# Altium schematic sheet Sheet.SchDoc(Sheet)
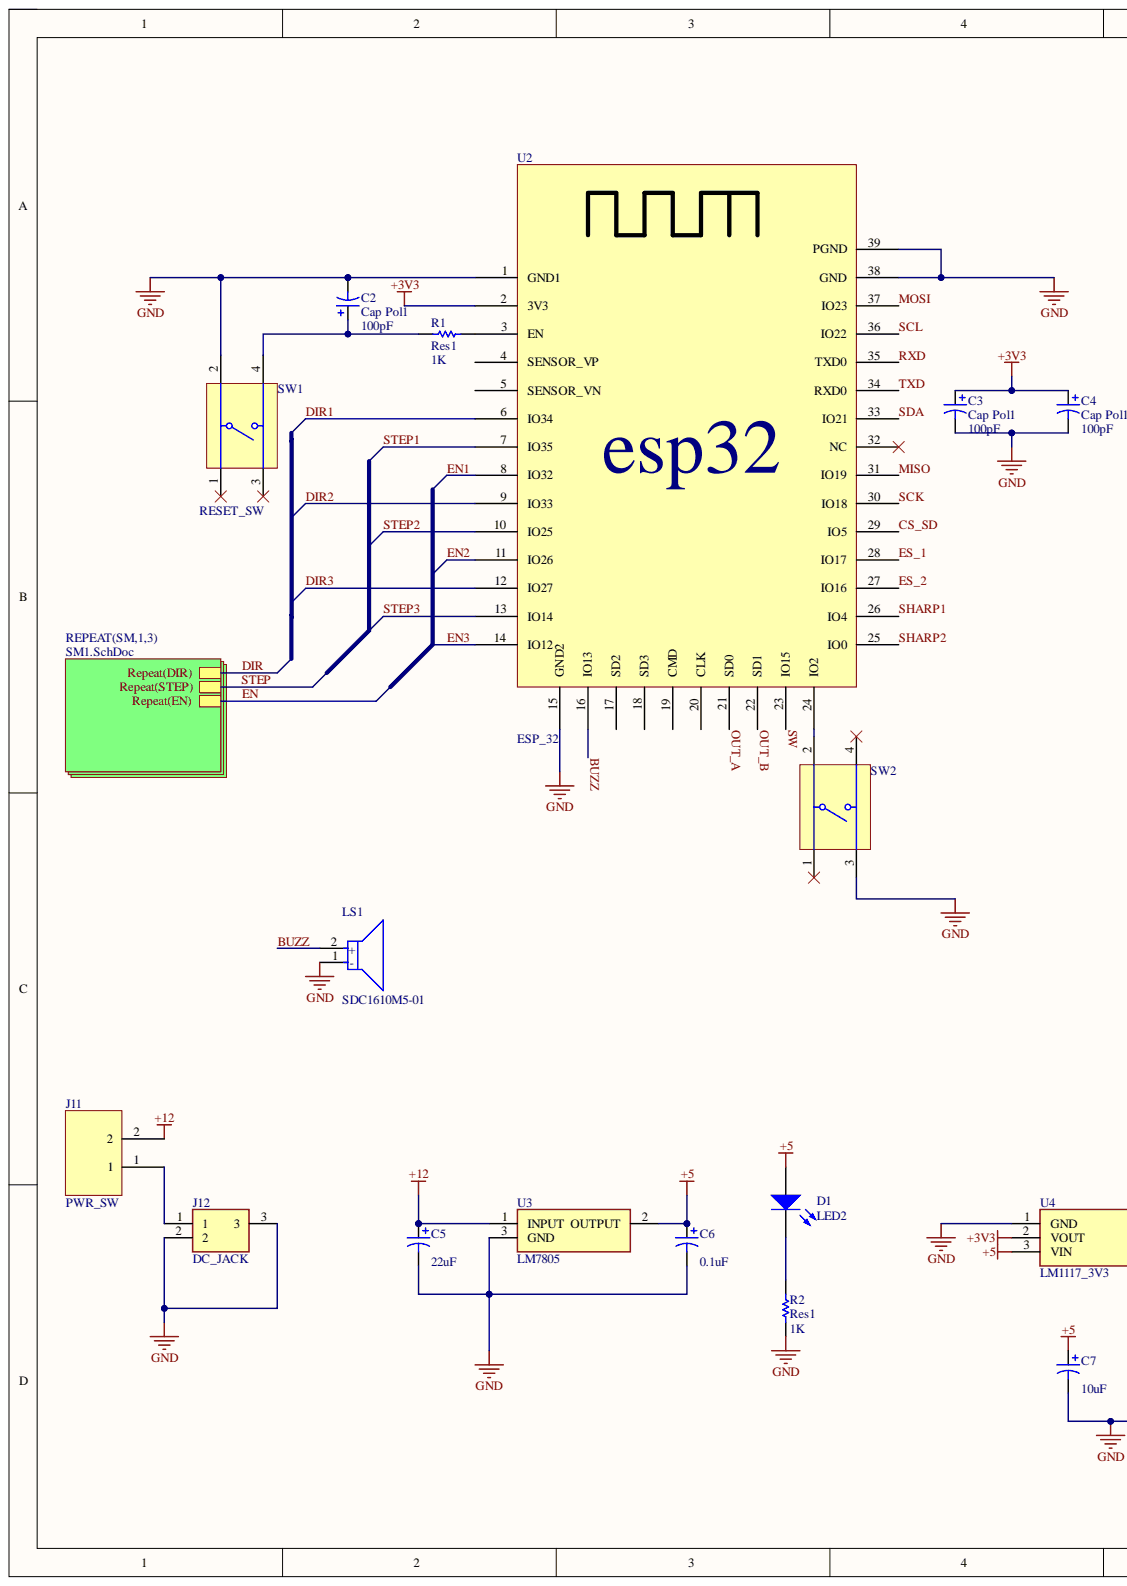
[diagram p0: Sheet.SchDoc, left]
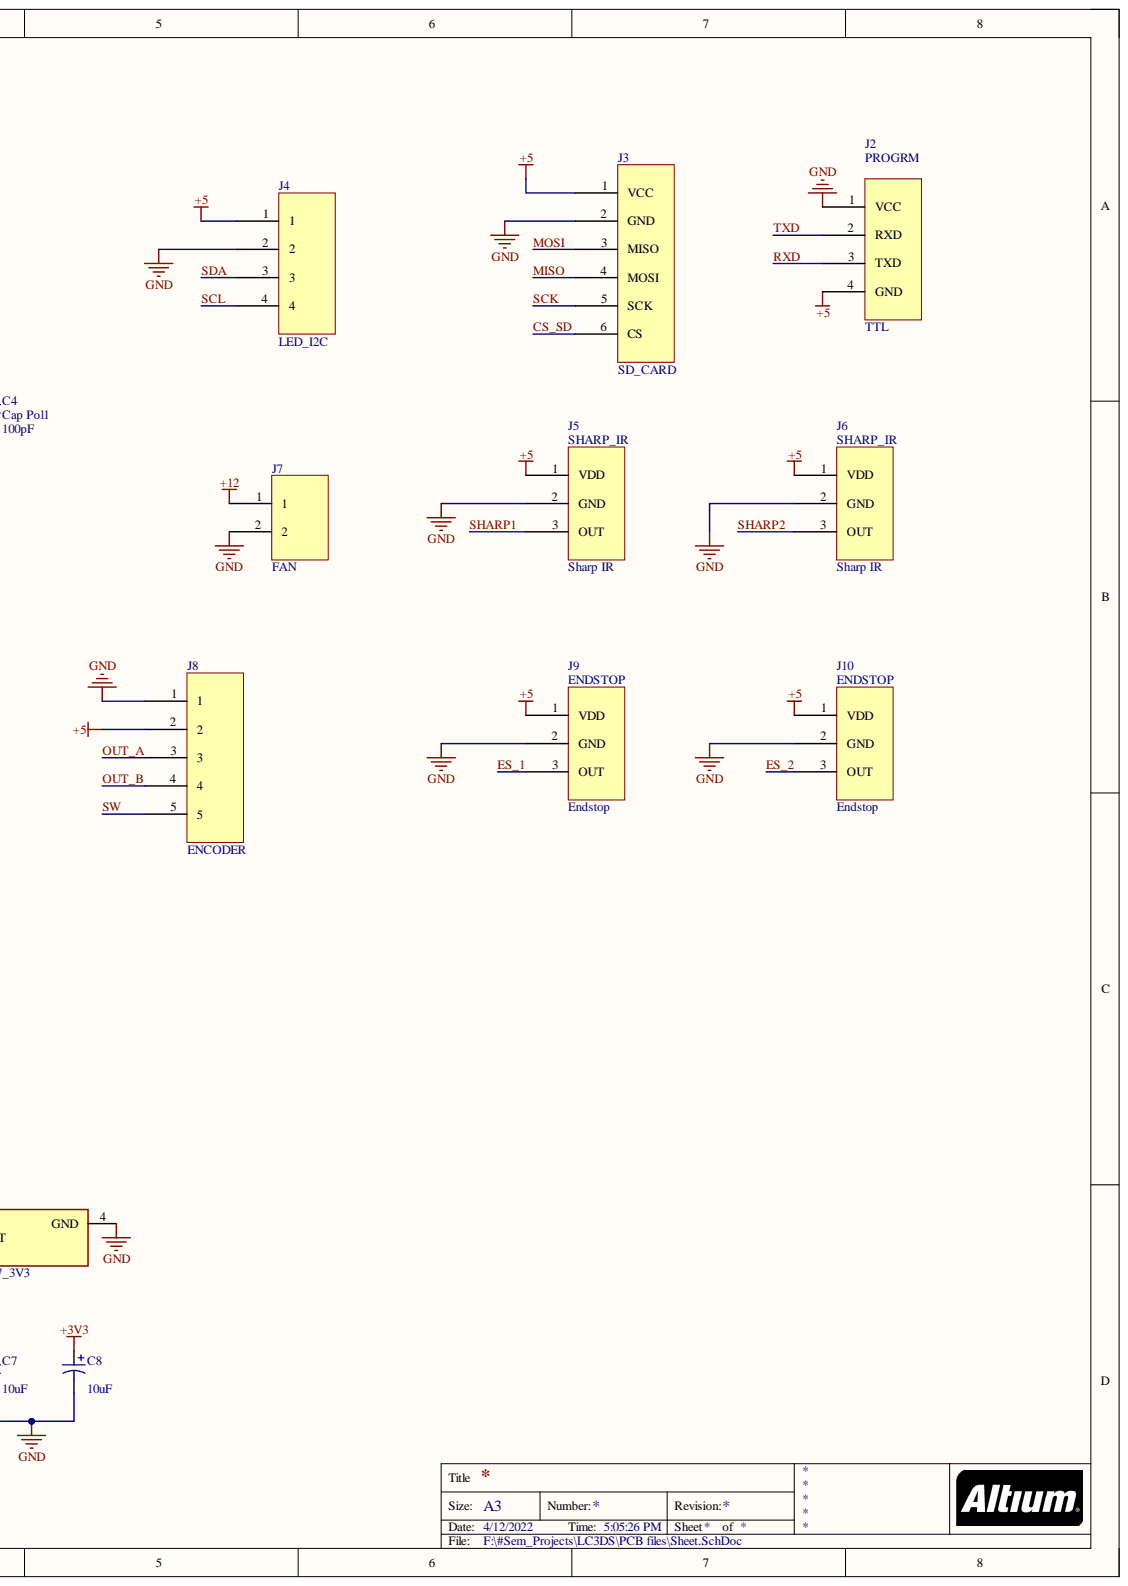
[diagram p1: Sheet.SchDoc, right]

## Parts
C2  Cap Pol1  value 100pF  [6-0805_N]
C3  Cap Pol1  value 100pF  [6-0805_N]
C4  Cap Pol1  value 100pF  [6-0805_N]
C5  value 22uF  [6-0805_N]  (hidden comment: Cap Pol1)
C6  value 0.1uF  [6-0805_N]  (hidden comment: Cap Pol1)
C7  value 10uF  [6-0805_N]  (hidden comment: Cap Pol1)
C8  value 10uF  [6-0805_N]  (hidden comment: Cap Pol1)
D1  LED2  [3.2X1.6X1.1]
J2  TTL  [TTL]
J3  SD_CARD  [SD_CARD]
J4  LED_I2C  [JST_4P]
J5  Sharp IR  [SHARP_IR]
J6  Sharp IR  [SHARP_IR]
J7  FAN  [JST_2P]
J8  ENCODER  [JST_5P]
J9  Endstop  [ENDSTOP]
J10  Endstop  [ENDSTOP]
J11  PWR_SW  [JST_2P]
J12  DC_JACK  [DC_JACK]
LS1  SDC1610M5-01  [FP-SDC1610M5-01-MFG]
R1  Res1  value 1K  [6-0805_N]
R2  Res1  value 1K  [6-0805_N]
SW1  RESET_SW  [TECO-1825910-6_V]
SW2  1825910-6  [TECO-1825910-6_V]  (value not drawn)
U2  ESP_32  [ESP_SoC_Module]
U3  LM7805  [LM7805]
U4  LM1117_3V3  [LM1117_3V3]

## Nets
+3V3: C3.1 C4.1 C8.1 U2.2(3V3) U4.2(VOUT)
+5: C6.1 C7.1 D1.1 J2.4(GND) J3.1(VCC) J4.1 J5.1 J6.1 J8.2 J9.1 J10.1 U3.2 U4.3(VIN)
+12: C5.1 J7.1 J11.2 U3.1
GND: C2.2 C3.2 C4.2 C5.2 C6.2 C7.2 C8.2 J2.1(VCC) J3.2(GND) J4.2 J5.2 J6.2 J7.2 J8.1 J9.2 J10.2 J12.2 J12.3 LS1.1 R2.1 SW1.2 SW2.3 U2.1(GND1) U2.15(GND2) U2.38(GND) U2.39(PGND) U3.3 U4.1(GND) U4.4(GND)
BUZZ: LS1.2 U2.16(IO13)
CS_SD: J3.6(CS) U2.29(IO5)
DIR1: U2.6(IO34)
DIR2: U2.9(IO33)
DIR3: U2.12(IO27)
EN1: U2.8(IO32)
EN2: U2.11(IO26)
EN3: U2.14(IO12)
ES_1: J9.3 U2.28(IO17)
ES_2: J10.3 U2.27(IO16)
MISO: J3.4(MOSI) U2.31(IO19)
MOSI: J3.3(MISO) U2.37(IO23)
OUT_A: J8.3 U2.21(SD0)
OUT_B: J8.4 U2.22(SD1)
RXD: J2.3(TXD) U2.35(TXD0)
SCK: J3.5(SCK) U2.30(IO18)
SCL: J4.4 U2.36(IO22)
SDA: J4.3 U2.33(IO21)
SHARP1: J5.3 U2.26(IO4)
SHARP2: J6.3 U2.25(IO0)
STEP1: U2.7(IO35)
STEP2: U2.10(IO25)
STEP3: U2.13(IO14)
SW: J8.5 U2.23(IO15)
TXD: J2.2(RXD) U2.34(RXD0)
NetC2_1: C2.1 R1.1 SW1.4
NetD1_2: D1.2 R2.2
NetJ11_1: J11.1 J12.1
NetR1_2: R1.2 U2.3(EN)
NetSW1_1: SW1.1
NetSW1_3: SW1.3
NetSW2_1: SW2.1
NetSW2_2: SW2.2 U2.24(IO2)
NetSW2_4: SW2.4
NetU2_4: U2.4(SENSOR_VP)
NetU2_5: U2.5(SENSOR_VN)
NetU2_17: U2.17(SD2)
NetU2_18: U2.18(SD3)
NetU2_19: U2.19(CMD)
NetU2_20: U2.20(CLK)
NetU2_32: U2.32(NC)

## Library data of the parts
C2-C8 = Cap Pol1: Polarized Capacitor (Radial); Component Kind: Standard; LatestRevisionDate: 17-Jul-2002; LatestRevisionNote: Re-released for DXP Platform.; Library Name: Miscellaneous Devices.SchLib; PackageDescription: Polarized Capacitor (Radial); 2 Leads; PackageReference: RB7.6-15; Published: 8-Jun-2000; Signal Integrity: Cap Pol; Simulation: CAP; Publisher: Altium Limited
D1 = LED2: Typical RED, GREEN, YELLOW, AMBER GaAs LED; Component Kind: Standard; LatestRevisionDate: 17-Jul-2002; LatestRevisionNote: Re-released for DXP Platform.; Library Name: Miscellaneous Devices.IntLib; PackageDescription: SM LED; 2 Flat Leads; PackageReference: 3.2X1.6X1.1; PackageVersion: Feb-2002; Published: 8-Jun-2000; Simulation: LED2; Publisher: Altium Limited
J4 = JST_4P: Component Kind: Standard; Library Name: Schlib.SchLib
J5 J6 = SHARP_IR: Component Kind: Standard; Library Name: Schlib.SchLib
J7 J11 = JST_2P: Component Kind: Standard; Library Name: Schlib.SchLib
J8 = JST_5P: Component Kind: Standard; Library Name: Schlib.SchLib
LS1 = CMP-08413-000008-1: AUDIO MAGNETIC INDICATOR 4-8V TH; Frequency: 2.4kHz; Input Type: DC; Max Supply Current: 30mA; Nominal Supply Voltage (DC): 5V; Number of Terminals/Pins: 2; Component Kind: Standard; Diameter: 16mm; Height: 10mm; Lead Free: Lead Free; Library Name: Altium Content Vault; Max Operating Temperature: 70°C; Min Operating Temperature: -10°C; Mount: Through Hole; Number of Pins: 2; Operating Supply Current: 30mA; Packaging: Tray; Sensing Method: Magnetic; Series: SDC; Termination: PC Pins; Voltage Rating: 5V
R1 R2 = Res1: Resistor; Component Kind: Standard; LatestRevisionDate: 17-Jul-2002; LatestRevisionNote: Re-released for DXP Platform.; Library Name: Miscellaneous Devices.IntLib; PackageDescription: Axial Device, Thru-Hole; 2 Leads; 0.3 in Pin Spacing; PackageReference: AXIAL-0.3; Published: 8-Jun-2000; Signal Integrity: Res; Simulation: RESISTOR; Publisher: Altium Limited
SW1 = CMP-1684-00021-1: Tact Switch, SPST-NO, 0.05 A, -35 to 85 degC, 4-Pin THD, RoHS, Bulk; Manufacturer: TE Connectivity; Part No: 1825910-6; Actuator Color: Black; Actuator Length: 1.4mm; Actuator Material: PolybutyleneTerephthalate; Actuator Style: RoundButton; Body Orientation: Straight; Bushing Type: Unthreaded; Contact Rating @ Voltage: 0.05A@24VDC; Dielectric Strength: 500VAC; Electrical Life: 100000Cycles; Function: MomentaryContact; Illumination: N/R; Maximum Contact Resistance: 100mOhm; Maximum DC Voltage Rating: 24V; Pole Throw Configuration: SPST; Switch Configuration: OFF(ON); Base Material: N/A; Component Kind: Standard; Contact Material: CopperAlloy; Contact Plating: Silver; Datasheet URL: http://www.te.com/commerce/DocumentDelivery/DDEController?Action=showdoc&DocId=Customer+Drawing%7F1825910%7FC9%7Fpdf%7FEnglish%7FENG_CD_1825910_C9.pdf%7F1825910-6; Datasheet Version: Rev. C9; Dimension: 5.99x5mm; Family: 1825910; Housing Material: N/A; Library Name: Altium Content Vault; Manufacturer URL: http://www.te.com; Maximum AC Voltage Rating: N/A; Mechanical Life: 100000Cycles; Minimum Insulation Resistance: 100MOhm; Mounting: ThroughHole; Operating Temperature: -35to85C; Package Description: 4-Pin Through Hole Device, Body 5.99 x 5.99 mm, Pitch 6.5 mm; Package Reference: THD; Packaging: N/A; Product Weight: N/A; RoHS: Yes; Seal Description: N/A; Seal Location: N/A; Terminal Type: PCPins; Thread Size: N/R
SW2 = CMP-1684-00021-1: Tact Switch, SPST-NO, 0.05 A, -35 to 85 degC, 4-Pin THD, RoHS, Bulk; Manufacturer: TE Connectivity; Actuator Color: Black; Actuator Length: 1.4mm; Actuator Material: PolybutyleneTerephthalate; Actuator Style: RoundButton; Body Orientation: Straight; Bushing Type: Unthreaded; Contact Rating @ Voltage: 0.05A@24VDC; Dielectric Strength: 500VAC; Electrical Life: 100000Cycles; Function: MomentaryContact; Illumination: N/R; Maximum Contact Resistance: 100mOhm; Maximum DC Voltage Rating: 24V; Pole Throw Configuration: SPST; Switch Configuration: OFF(ON); Base Material: N/A; Component Kind: Standard; Contact Material: CopperAlloy; Contact Plating: Silver; Datasheet URL: http://www.te.com/commerce/DocumentDelivery/DDEController?Action=showdoc&DocId=Customer+Drawing%7F1825910%7FC9%7Fpdf%7FEnglish%7FENG_CD_1825910_C9.pdf%7F1825910-6; Datasheet Version: Rev. C9; Dimension: 5.99x5mm; Family: 1825910; Housing Material: N/A; Library Name: Altium Content Vault; Manufacturer URL: http://www.te.com; Maximum AC Voltage Rating: N/A; Mechanical Life: 100000Cycles; Minimum Insulation Resistance: 100MOhm; Mounting: ThroughHole; Operating Temperature: -35to85C; Package Description: 4-Pin Through Hole Device, Body 5.99 x 5.99 mm, Pitch 6.5 mm; Package Reference: THD; Packaging: N/A; Part No: 1825910-6; Product Weight: N/A; RoHS: Yes; Seal Description: N/A; Seal Location: N/A; Terminal Type: PCPins; Thread Size: N/R
U2 = ESP_SoC_Module: Component Kind: Standard; Library Name: Schlib.SchLib
J2 = TTL: Component Kind: Standard; Library Name: Schlib.SchLib
J3 = SD_CARD: Component Kind: Standard; Library Name: Schlib.SchLib
J9 J10 = ENDSTOP: Component Kind: Standard; Library Name: Schlib.SchLib
J12 = DC_JACK: Component Kind: Standard; Library Name: Schlib.SchLib
U3 = LM7805: Component Kind: Standard; Library Name: Schlib.SchLib
U4 = LM1117_3V3: Component Kind: Standard; Library Name: Schlib.SchLib
